# Altium schematic sheet PIN(3~4).SchDoc(PIN(3~4))
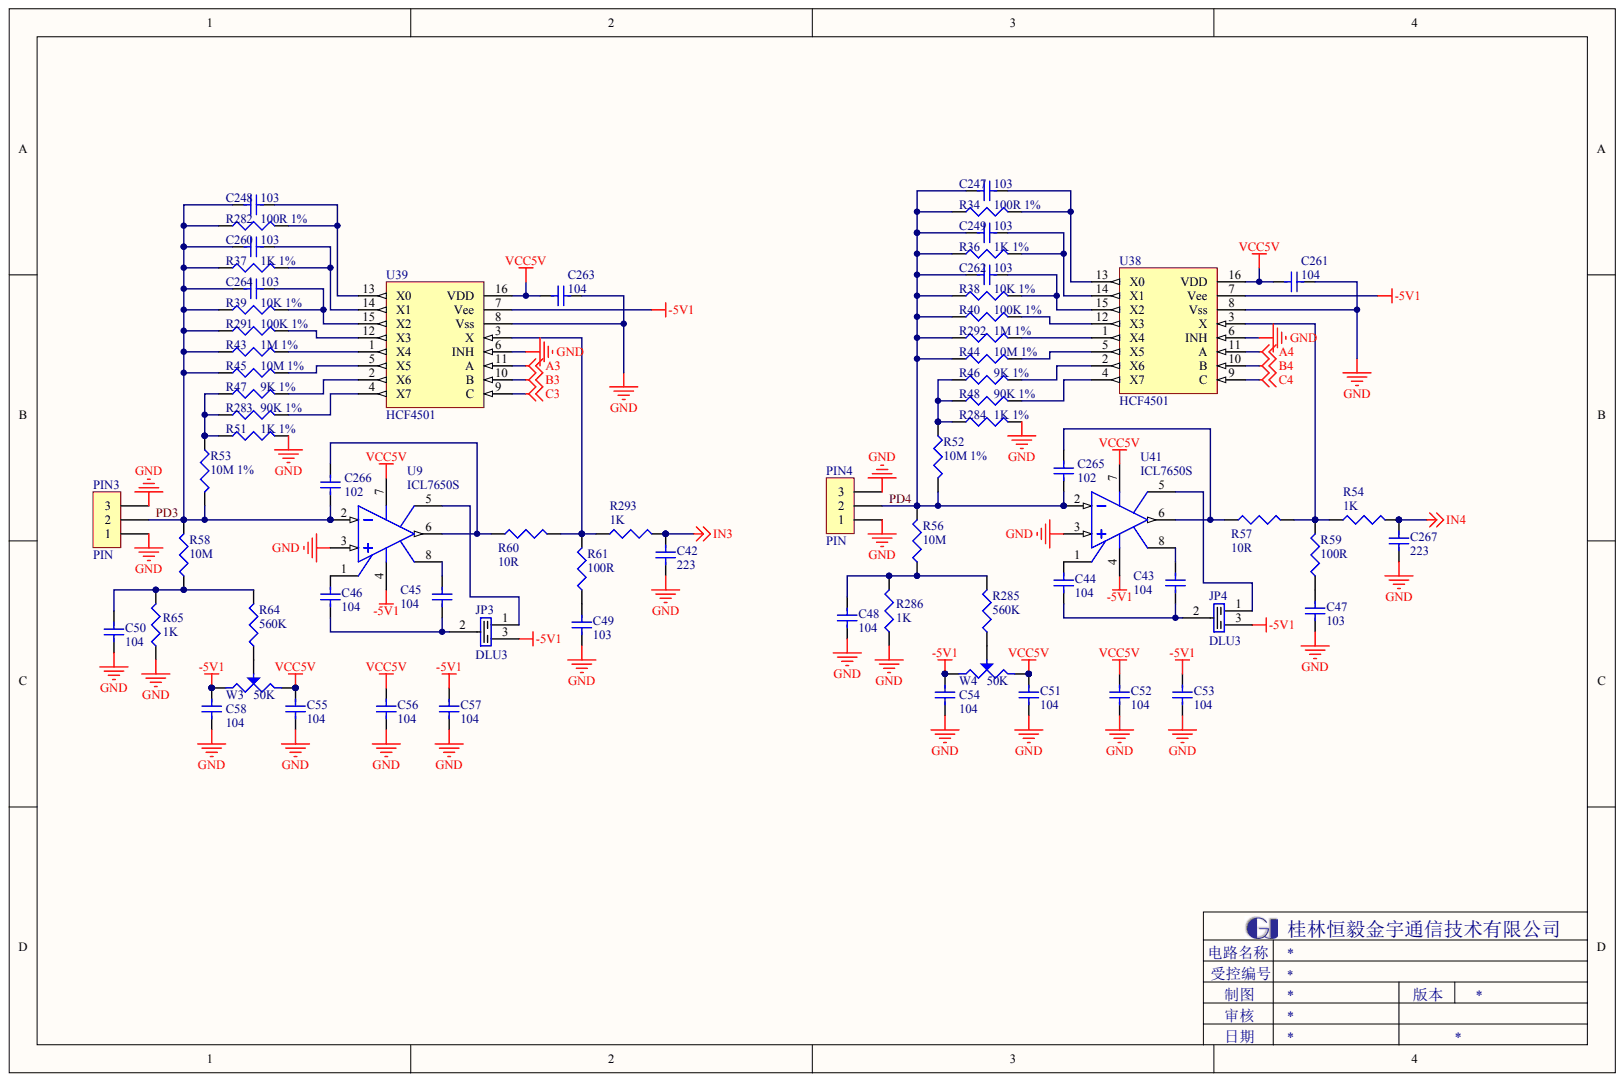
[diagram p0: PIN]

## Parts
C42  223
C43  104
C44  104
C45  104
C46  104
C47  103
C48  104
C49  103
C50  104
C51  104
C52  104
C53  104
C54  104
C55  104
C56  104
C57  104
C58  104
C247  103
C248  103
C249  103
C260  103
C261  104
C262  103
C263  104
C264  103
C265  102
C266  102
C267  223
JP3  DLU3
JP4  DLU3
PIN3  PIN
PIN4  PIN
R34  100R 1%
R36  1K 1%
R37  1K 1%
R38  10K 1%
R39  10K 1%
R40  100K 1%
R43  1M 1%
R44  10M 1%
R45  10M 1%
R46  9K 1%
R47  9K 1%
R48  90K 1%
R51  1K 1%
R52  10M 1%
R53  10M 1%
R54  1K
R56  10M
R57  10R
R58  10M
R59  100R
R60  10R
R61  100R
R64  560K
R65  1K
R282  100R 1%
R283  90K 1%
R284  1K 1%
R285  560K
R286  1K
R291  100K 1%
R292  1M 1%
R293  1K
U9  ICL7650S
U38  HCF4501
U39  HCF4501
U41  ICL7650S
W3  50K
W4  50K

## Nets
-5V1: C53.2 C54.1 C57.2 C58.1 JP3.3 JP4.3 U9.4 U38.7(Vee) U39.7(Vee) U41.4 W3.1 W4.1
A3: U39.11(A)
A4: U38.11(A)
B3: U39.10(B)
B4: U38.10(B)
C3: U39.9(C)
C4: U38.9(C)
GND: C42.1 C47.1 C48.1 C49.1 C50.1 C51.1 C52.1 C53.1 C54.2 C55.1 C56.1 C57.1 C58.2 C261.2 C263.2 C267.1 PIN3.1 PIN3.3 PIN4.1 PIN4.3 R51.2 R65.1 R284.2 R286.1 U9.3 U38.6(INH) U38.8(Vss) U39.6(INH) U39.8(Vss) U41.3
IN3: C42.2 R293.2
IN4: C267.2 R54.2
VCC5V: C51.2 C52.2 C55.2 C56.2 C261.1 C263.1 U9.7 U38.16(VDD) U39.16(VDD) U41.7 W3.3 W4.3
PD3: C248.1 C260.1 C264.1 C266.1 PIN3.2 R37.1 R39.1 R43.1 R45.1 R53.2 R58.2 R282.1 R291.1 U9.2
PD4: C247.1 C249.1 C262.1 C265.1 PIN4.2 R34.1 R36.1 R38.1 R40.1 R44.1 R52.2 R56.2 R292.1 U41.2
NetC43_1: C43.1 U41.8
NetC43_2: C43.2 C44.1 JP4.2
NetC44_2: C44.2 U41.1
NetC45_1: C45.1 U9.8
NetC45_2: C45.2 C46.1 JP3.2
NetC46_2: C46.2 U9.1
NetC47_2: C47.2 R59.1
NetC48_2: C48.2 R56.1 R285.2 R286.2
NetC49_2: C49.2 R61.1
NetC50_2: C50.2 R58.1 R64.2 R65.2
NetC247_2: C247.2 R34.2 U38.13(X0)
NetC248_2: C248.2 R282.2 U39.13(X0)
NetC249_2: C249.2 R36.2 U38.14(X1)
NetC260_2: C260.2 R37.2 U39.14(X1)
NetC262_2: C262.2 R38.2 U38.15(X2)
NetC264_2: C264.2 R39.2 U39.15(X2)
NetC265_2: C265.2 R57.1 U41.6
NetC266_2: C266.2 R60.1 U9.6
NetJP3_1: JP3.1 U9.5
NetJP4_1: JP4.1 U41.5
NetR40_2: R40.2 U38.12(X3)
NetR43_2: R43.2 U39.1(X4)
NetR44_2: R44.2 U38.5(X5)
NetR45_2: R45.2 U39.5(X5)
NetR46_1: R46.1 R48.1 R52.1 R284.1
NetR46_2: R46.2 U38.2(X6)
NetR47_1: R47.1 R51.1 R53.1 R283.1
NetR47_2: R47.2 U39.2(X6)
NetR48_2: R48.2 U38.4(X7)
NetR54_1: R54.1 R57.2 R59.2 U38.3(X)
NetR60_2: R60.2 R61.2 R293.1 U39.3(X)
NetR64_1: R64.1 W3.2
NetR283_2: R283.2 U39.4(X7)
NetR285_1: R285.1 W4.2
NetR291_2: R291.2 U39.12(X3)
NetR292_2: R292.2 U38.1(X4)
